# Altium schematic sheet 12-Dash_Inputs.SchDoc(12-Dash_Inputs)
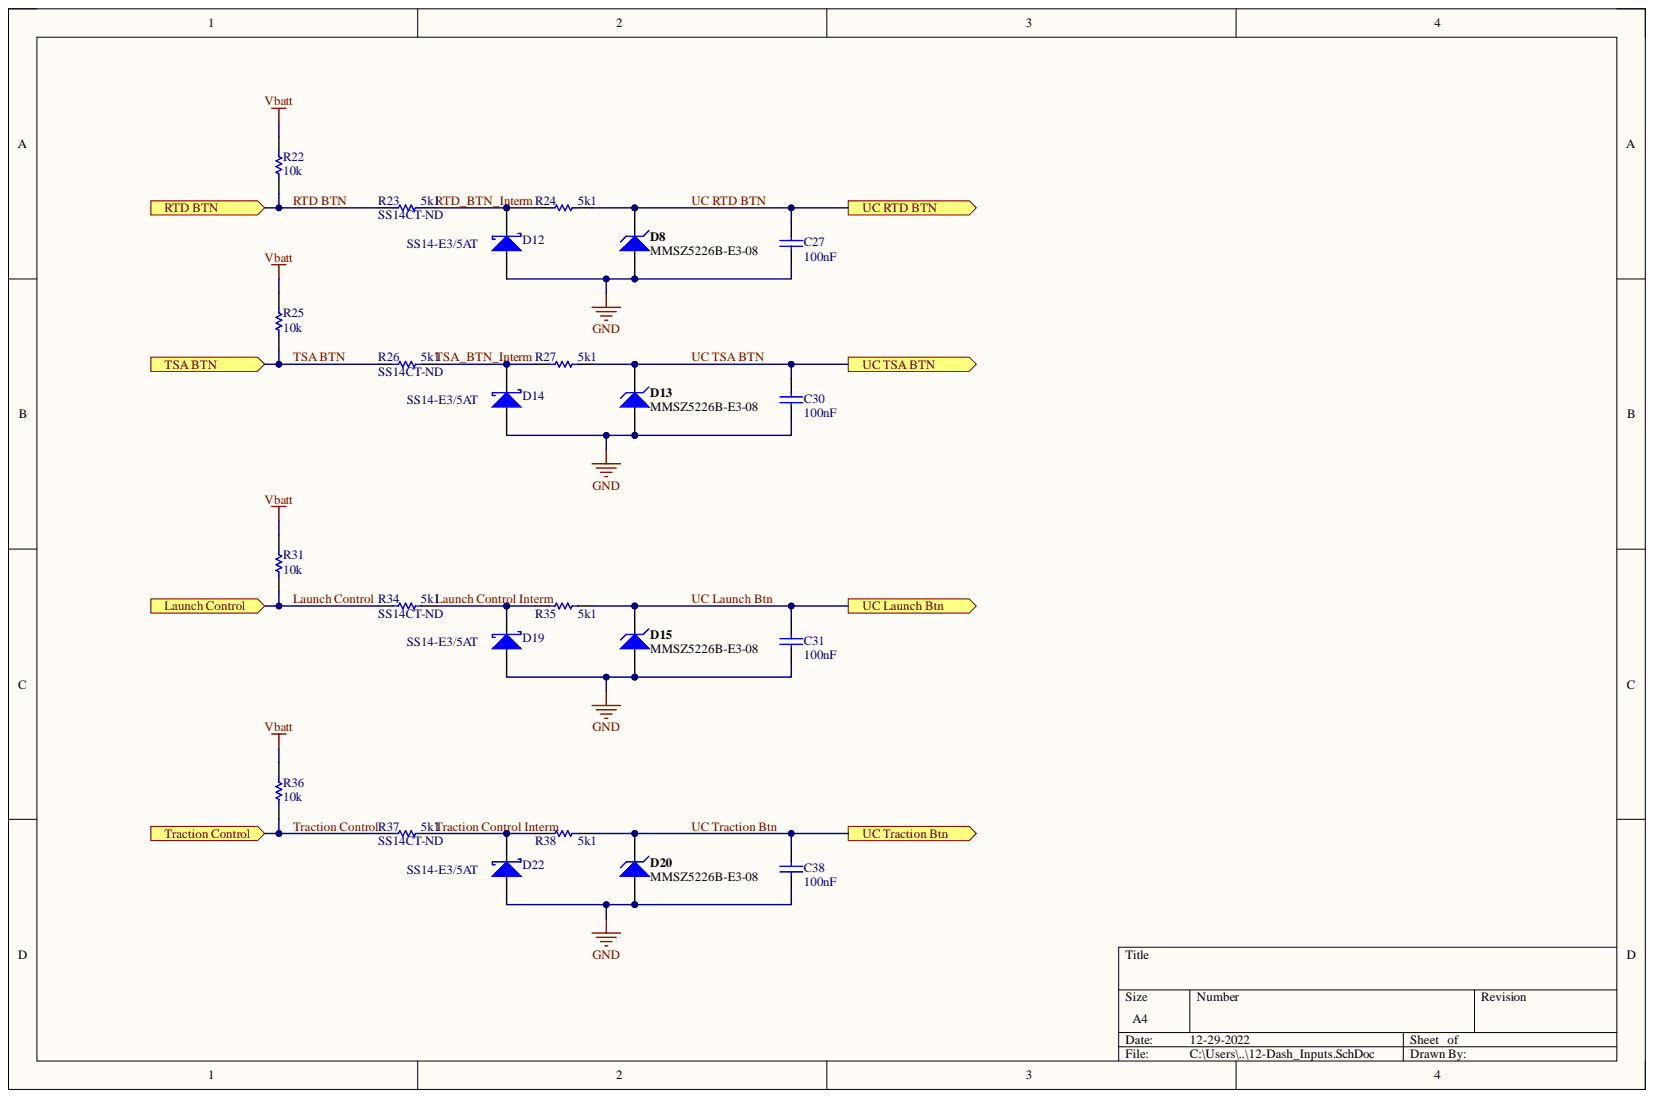
[diagram p0: 12-Dash_Inputs.SchDoc]

## Parts
C27  value 100nF  [1608[0603]]  (hidden comment: Cap)
C30  value 100nF  [1608[0603]]  (hidden comment: Cap)
C31  value 100nF  [1608[0603]]  (hidden comment: Cap)
C38  value 100nF  [1608[0603]]  (hidden comment: Cap)
D8  MMSZ5226B-E3-08  [VISH-SOD-123-2_V]
D12  SS14-E3/5AT  [VISH-DO-214AC-2_V]
D13  MMSZ5226B-E3-08  [VISH-SOD-123-2_V]
D14  SS14-E3/5AT  [VISH-DO-214AC-2_V]
D15  MMSZ5226B-E3-08  [VISH-SOD-123-2_V]
D19  SS14-E3/5AT  [VISH-DO-214AC-2_V]
D20  MMSZ5226B-E3-08  [VISH-SOD-123-2_V]
D22  SS14-E3/5AT  [VISH-DO-214AC-2_V]
R22  value 10k  Resistance (Ohms): 10k  [1608[0603]]  (hidden comment: Res1)
R23  value 5k1  [1608[0603]]  (hidden comment: Res1)
R24  value 5k1  [1608[0603]]  (hidden comment: Res1)
R25  value 10k  Resistance (Ohms): 10k  [1608[0603]]  (hidden comment: Res1)
R26  value 5k1  [1608[0603]]  (hidden comment: Res1)
R27  value 5k1  [1608[0603]]  (hidden comment: Res1)
R31  value 10k  Resistance (Ohms): 10k  [1608[0603]]  (hidden comment: Res1)
R34  value 5k1  [1608[0603]]  (hidden comment: Res1)
R35  value 5k1  [1608[0603]]  (hidden comment: Res1)
R36  value 10k  Resistance (Ohms): 10k  [1608[0603]]  (hidden comment: Res1)
R37  value 5k1  [1608[0603]]  (hidden comment: Res1)
R38  value 5k1  [1608[0603]]  (hidden comment: Res1)

## Ports
Launch Control, RTD BTN, Traction Control, TSA BTN, UC Launch Btn, UC RTD BTN, UC Traction Btn, UC TSA BTN

## Nets
GND: C27.1 C30.1 C31.1 C38.1 D8.1 D12.1 D13.1 D14.1 D15.1 D19.1 D20.1 D22.1
Vbatt: R22.2 R25.2 R31.2 R36.2
Launch Control: R31.1 R34.1 ; port Launch Control
Launch Control Interm: D19.2 R34.2 R35.1
RTD BTN: R22.1 R23.1 ; port RTD BTN
RTD_BTN_Interm: D12.2 R23.2 R24.1
Traction Control: R36.1 R37.1 ; port Traction Control
Traction Control Interm: D22.2 R37.2 R38.1
TSA BTN: R25.1 R26.1 ; port TSA BTN
TSA_BTN_Interm: D14.2 R26.2 R27.1
UC Launch Btn: C31.2 D15.2 R35.2 ; port UC Launch Btn
UC RTD BTN: C27.2 D8.2 R24.2 ; port UC RTD BTN
UC Traction Btn: C38.2 D20.2 R38.2 ; port UC Traction Btn
UC TSA BTN: C30.2 D13.2 R27.2 ; port UC TSA BTN

## Library data of the parts
C27 C30 C31 C38 = Cap: Capacitor; Manufacturer: Yageo; Manufacturer Part Number: CC0603KRX7R9BB104; Capacitance: 0.10µF; Temperature Coefficient: X7R; Tolerance: ±10%; Voltage - Rated: 50V; Applications: General Purpose; Category: Capacitors; Component Kind: Standard; ComponentLink1Description: http://www.yageo.com/documents/recent/UPY-GPHC_X7R_6.3V-to-50V_16.pdf; ComponentLink1URL: http://www.yageo.com/documents/recent/UPY-GPHC_X7R_6.3V-to-50V_16.pdf; Failure Rate: -; Features: -; Height - Seated (Max): -; Lead Spacing: -; Lead Style: -; Library Name: Miscellaneous Devices.IntLib; Mounting Type: Surface Mount, MLCC; Operating Temperature: -55°C ~ 125°C; Package / Case: 0603 (1608 Metric); Packaging: Cut Tape (CT); Part Status: Active; Pricing 1: 1=0.15, 10=0.043, 25=0.0312, 50=0.0232, 100=0.0189, 250=0.0158, 500=0.01346, 1000=0.01059 (CAD); Ratings: -; RoHS: RoHS Compliant; Simulation: CAP; Size / Dimension: 0.063" L x 0.031" W (1.60mm x 0.80mm); Stock 1: 4016262; Supplier 1: Digi-Key; Supplier Part Number 1: 311-1344-1-ND; Thickness (Max): 0.035" (0.90mm)
D8 D13 D15 D20 = CMP-0931-00026-1: Small Signal Zener Diode, 3.3 V +/- 5%, -55 to 155 degC, 2-Pin SOD-123, RoHS, Tape and Reel; Manufacturer: Vishay Semiconductor; Manufacturer Part Number: MMSZ5226B-7-F; Current - Reverse Leakage @ Vr: 25µA @ 1V; Impedance (Max) (Zzt): 28 Ohm; Int. Construction: Single; Power - Max: 500mW; Power Dissipation (mW): 500; Test Current (IZT1) (mA): 20; Tolerance: +/- 5%; Voltage - Forward (Vf) (Max) @ If: 900mV @ 10mA; Voltage - Zener (Nom) (Vz): 3.3V; Zener Impedance (IZT1) (Max.) (Ohm): 28; Zener Voltage (NOM.) (V): 3.3; Category: Discrete Semiconductor Products; Component Kind: Standard; ComponentLink1Description: Manufacturer URL; ComponentLink1URL: http://www.vishay.com/; ComponentLink2Description: Datasheet; ComponentLink2URL: http://www.vishay.com/docs/85774/mmsz5225.pdf; ComponentLink3Description: Package Specification; ComponentLink3URL: http://www.vishay.com/docs/85774/mmsz5225.pdf; ComponentLink4Description: http://www.diodes.com/_files/datasheets/ds18010.pdf; ComponentLink4URL: http://www.diodes.com/_files/datasheets/ds18010.pdf; DatasheetVersion: Rev. 1.9; Library Name: Altium Content Vault; Mounting Technology: Surface Mount; Mounting Type: Surface Mount; Operating Temperature: -55 to 150 degC; Package / Case: SOD-123; PackageDescription: 2-Pin SMD, Body 2.7 x 1.55 mm, Height 1.35 mm; PackageReference: SOD-123-2; PackageVersion: Rev. 1.9; Packaging: Cut Tape (CT); Packing: Tape and Reel; Part Status: Active; PartNumber: MMSZ5226B-E3-08; Pricing 1: 1=0.29, 10=0.263, 100=0.1426, 500=0.08778, 1000=0.05985 (CAD); RoHS: TRUE; Stock 1: 45935; Supplier 1: Digi-Key; Supplier Device Package: SOD-123; Supplier Part Number 1: MMSZ5226B-FDICT-ND
D12 D14 D19 D22 = CMP-0549-00004-1: Schottky Barrier Rectifier, 40 V Maximum DC Blocking Voltage, -65 to 125 degC, 2-Pin SMA Case, RoHS; Manufacturer: Vishay Semiconductor; IF(AV): 1 A Max.; IFSM: 40 A; VDC: 40 V Max.; VRMS: 28 V Max.; Component Kind: Standard; ComponentLink1Description: Manufacturer URL; ComponentLink1URL: http://www.vishay.com/; ComponentLink2Description: Datasheet; ComponentLink2URL: http://www.vishay.com/docs/88746/ss12.pdf; ComponentLink3Description: Package Specification; ComponentLink3URL: http://www.vishay.com/docs/88746/ss12.pdf; DatasheetVersion: 12/17/2012; Library Name: Altium Content Vault; Mounting Technology: Surface Mount; Operating Temperature: -65 to 125 degC; PackageDescription: 2-Pin SMD, Body 4.245 x 2.665 mm, Height 2.29 mm; PackageReference: DO-214AC-2; PackageVersion: Dec-12; PartNumber: SS14-E3/5AT; RoHS: TRUE; Supplier 1: Digi-Key; Supplier Part Number 1: SS14CT-ND; VRRM: 40 V Max.
R22 R25 R31 R36 = Res1: Resistor; Manufacturer: Yageo; Manufacturer Part Number: RC0603JR-0710KL; Power (Watts): 0.1W, 1/10W; Temperature Coefficient: ±100ppm/°C; Tolerance: ±5%; Category: Resistors; Component Kind: Standard; ComponentLink1Description: http://www.yageo.com/NewPortal/yageodocoutput?fileName=/pdf/R-Chip/PYu-RC_Group_51_RoHS_L_4.pdf; ComponentLink1URL: http://www.yageo.com/NewPortal/yageodocoutput?fileName=/pdf/R-Chip/PYu-RC_Group_51_RoHS_L_4.pdf; Composition: Thick Film; Failure Rate: -; Features: Moisture Resistant; Height - Seated (Max): 0.022" (0.55mm); Library Name: Miscellaneous Devices.IntLib; Number of Terminations: 2; Operating Temperature: -55°C ~ 155°C; Package / Case: 0603 (1608 Metric); Packaging: Cut Tape (CT); Part Status: Active; Pricing 1: 1=0.16, 10=0.016, 25=0.0112, 50=0.0084, 100=0.0062, 250=0.0048, 500=0.00382, 1000=0.00281 (CAD); RoHS: RoHS Compliant; Simulation: RESISTOR; Size / Dimension: 0.063" L x 0.031" W (1.60mm x 0.80mm); Stock 1: 2936292; Supplier 1: Digi-Key; Supplier Device Package: 0603; Supplier Part Number 1: 311-10KGRCT-ND
R23 R24 R26 R27 R34 R35 R37 R38 = Res1: Resistor; Manufacturer: Yageo; Manufacturer Part Number: RC0603JR-075K1L; Power (Watts): 0.1W, 1/10W; Resistance (Ohms): 5.1k; Temperature Coefficient: ±100ppm/°C; Tolerance: ±5%; Category: Resistors; Component Kind: Standard; ComponentLink1Description: http://www.yageo.com/NewPortal/yageodocoutput?fileName=/pdf/R-Chip/PYu-RC_Group_51_RoHS_L_4.pdf; ComponentLink1URL: http://www.yageo.com/NewPortal/yageodocoutput?fileName=/pdf/R-Chip/PYu-RC_Group_51_RoHS_L_4.pdf; Composition: Thick Film; Failure Rate: -; Features: Moisture Resistant; Height - Seated (Max): 0.022" (0.55mm); Library Name: Miscellaneous Devices.IntLib; Number of Terminations: 2; Operating Temperature: -55°C ~ 155°C; Package / Case: 0603 (1608 Metric); Packaging: Cut Tape (CT); Part Status: Active; Pricing 1: 1=0.16, 10=0.016, 25=0.0112, 50=0.0084, 100=0.0062, 250=0.0048, 500=0.00382, 1000=0.00281 (CAD); RoHS: RoHS Compliant; Simulation: RESISTOR; Size / Dimension: 0.063" L x 0.031" W (1.60mm x 0.80mm); Stock 1: 782184; Supplier 1: Digi-Key; Supplier Device Package: 0603; Supplier Part Number 1: 311-5.1KGRCT-ND
